# Altium schematic sheet IOs.SchDoc
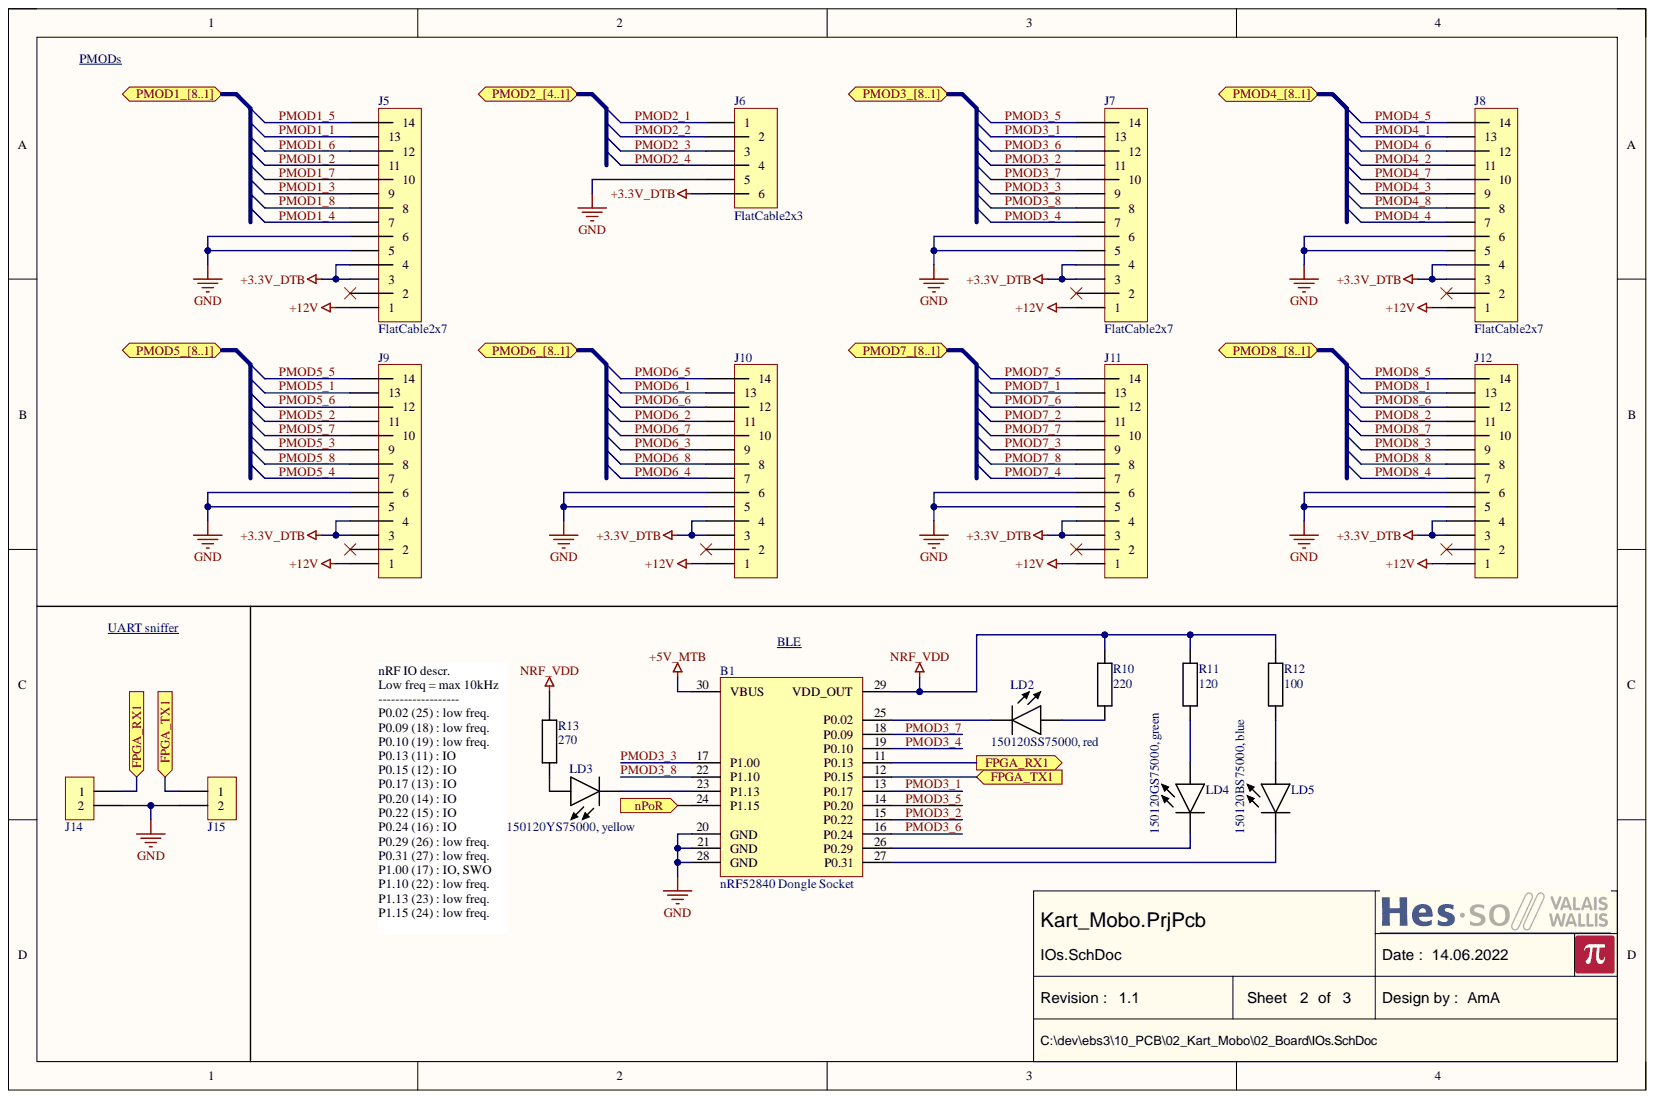
[diagram p0: IOs.SchDoc]

## Parts
B1  nRF52840 Dongle Socket  [nRF52840 Dongle socket]
J5  FlatCable2x7  [FlatCable2x7 2.54MM Straight TH]
J6  FlatCable2x3  [FlatCable2x3 2.54MM Straight TH]
J7  FlatCable2x7  [FlatCable2x7 2.54MM Straight TH]
J8  FlatCable2x7  [FlatCable2x7 2.54MM Straight TH]
J9  Socket2x7  [Socket2X7 P2.54 RIGHT ANGLE]  (value not drawn)
J10  Socket2x7  [Socket2X7 P2.54 RIGHT ANGLE]  (value not drawn)
J11  Socket2x7  [Socket2X7 P2.54 RIGHT ANGLE]  (value not drawn)
J12  Socket2x7  [Socket2X7 P2.54 RIGHT ANGLE]  (value not drawn)
J14  (no value)  [PinHeader1x2 P2.54]
J15  (no value)  [PinHeader1x2 P2.54]
LD2  150120SS75000, red  [LED 3216 REFLOW]
LD3  150120YS75000, yellow  [LED 3216 REFLOW]
LD4  150120GS75000, green  [LED 3216 REFLOW]
LD5  150120BS75000, blue  [LED 3216 REFLOW]
R10  220  [RESISTOR 1608 REFLOW]
R11  120  [RESISTOR 1608 REFLOW]
R12  100  [RESISTOR 1608 REFLOW]
R13  270  [RESISTOR 1608 REFLOW]

## Ports
FPGA_RX1, FPGA_TX1, nPoR, PMOD1_1, PMOD1_2, PMOD1_3, PMOD1_4, PMOD1_5, PMOD1_6, PMOD1_7, PMOD1_8, PMOD1_[8..1], PMOD2_1, PMOD2_2, PMOD2_3, PMOD2_4, PMOD2_[4..1], PMOD3_1, PMOD3_2, PMOD3_3, PMOD3_4, PMOD3_5, PMOD3_6, PMOD3_7, PMOD3_8, PMOD3_[8..1], PMOD4_1, PMOD4_2, PMOD4_3, PMOD4_4, PMOD4_5, PMOD4_6, PMOD4_7, PMOD4_8, PMOD4_[8..1], PMOD5_1, PMOD5_2, PMOD5_3, PMOD5_4, PMOD5_5, PMOD5_6, PMOD5_7, PMOD5_8, PMOD5_[8..1], PMOD6_1, PMOD6_2, PMOD6_3, PMOD6_4, PMOD6_5, PMOD6_6, PMOD6_7, PMOD6_8, PMOD6_[8..1], PMOD7_1, PMOD7_2, PMOD7_3, PMOD7_4, PMOD7_5, PMOD7_6, PMOD7_7, PMOD7_8, PMOD7_[8..1], PMOD8_1, PMOD8_2, PMOD8_3, PMOD8_4, PMOD8_5, PMOD8_6, PMOD8_7, PMOD8_8, PMOD8_[8..1]

## Nets
+3.3V_DTB: J5.3 J5.4 J6.6 J7.3 J7.4 J8.3 J8.4 J9.3 J9.4 J10.3 J10.4 J11.3 J11.4 J12.3 J12.4
+5V_MTB: B1.30(VBUS)
+12V: J5.1 J7.1 J8.1 J9.1 J10.1 J11.1 J12.1
GND: B1.20(GND) B1.21(GND) B1.28(GND) J5.5 J5.6 J6.5 J7.5 J7.6 J8.5 J8.6 J9.5 J9.6 J10.5 J10.6 J11.5 J11.6 J12.5 J12.6 J14.2 J15.2
NRF_VDD: B1.29(VDD_OUT) R10.1 R11.1 R12.2 R13.2
FPGA_RX1: B1.11(P0.13) J14.1 ; port FPGA_RX1
FPGA_TX1: B1.12(P0.15) J15.1 ; port FPGA_TX1
nPoR: B1.24(P1.15) ; port nPoR
PMOD1_1: J5.13 ; port PMOD1_[8..1]  [also labelled ]
PMOD1_2: J5.11 ; port PMOD1_[8..1]  [also labelled ]
PMOD1_3: J5.9 ; port PMOD1_[8..1]  [also labelled ]
PMOD1_4: J5.7 ; port PMOD1_[8..1]  [also labelled ]
PMOD1_5: J5.14 ; port PMOD1_[8..1]  [also labelled ]
PMOD1_6: J5.12 ; port PMOD1_[8..1]  [also labelled ]
PMOD1_7: J5.10 ; port PMOD1_[8..1]  [also labelled ]
PMOD1_8: J5.8 ; port PMOD1_[8..1]  [also labelled ]
PMOD2_1: J6.1 ; port PMOD2_[4..1]  [also labelled ]
PMOD2_2: J6.2 ; port PMOD2_[4..1]  [also labelled ]
PMOD2_3: J6.3 ; port PMOD2_[4..1]  [also labelled ]
PMOD2_4: J6.4 ; port PMOD2_[4..1]  [also labelled ]
PMOD3_1: B1.13(P0.17) J7.13 ; port PMOD3_[8..1]  [also labelled ]
PMOD3_2: B1.15(P0.22) J7.11 ; port PMOD3_[8..1]  [also labelled ]
PMOD3_3: B1.17(P1.00) J7.9 ; port PMOD3_[8..1]  [also labelled ]
PMOD3_4: B1.19(P0.10) J7.7 ; port PMOD3_[8..1]  [also labelled ]
PMOD3_5: B1.14(P0.20) J7.14 ; port PMOD3_[8..1]  [also labelled ]
PMOD3_6: B1.16(P0.24) J7.12 ; port PMOD3_[8..1]  [also labelled ]
PMOD3_7: B1.18(P0.09) J7.10 ; port PMOD3_[8..1]  [also labelled ]
PMOD3_8: B1.22(P1.10) J7.8 ; port PMOD3_[8..1]  [also labelled ]
PMOD4_1: J8.13 ; port PMOD4_[8..1]  [also labelled ]
PMOD4_2: J8.11 ; port PMOD4_[8..1]  [also labelled ]
PMOD4_3: J8.9 ; port PMOD4_[8..1]  [also labelled ]
PMOD4_4: J8.7 ; port PMOD4_[8..1]  [also labelled ]
PMOD4_5: J8.14 ; port PMOD4_[8..1]  [also labelled ]
PMOD4_6: J8.12 ; port PMOD4_[8..1]  [also labelled ]
PMOD4_7: J8.10 ; port PMOD4_[8..1]  [also labelled ]
PMOD4_8: J8.8 ; port PMOD4_[8..1]  [also labelled ]
PMOD5_1: J9.13 ; port PMOD5_[8..1]  [also labelled ]
PMOD5_2: J9.11 ; port PMOD5_[8..1]  [also labelled ]
PMOD5_3: J9.9 ; port PMOD5_[8..1]  [also labelled ]
PMOD5_4: J9.7 ; port PMOD5_[8..1]  [also labelled ]
PMOD5_5: J9.14 ; port PMOD5_[8..1]  [also labelled ]
PMOD5_6: J9.12 ; port PMOD5_[8..1]  [also labelled ]
PMOD5_7: J9.10 ; port PMOD5_[8..1]  [also labelled ]
PMOD5_8: J9.8 ; port PMOD5_[8..1]  [also labelled ]
PMOD6_1: J10.13 ; port PMOD6_[8..1]  [also labelled ]
PMOD6_2: J10.11 ; port PMOD6_[8..1]  [also labelled ]
PMOD6_3: J10.9 ; port PMOD6_[8..1]  [also labelled ]
PMOD6_4: J10.7 ; port PMOD6_[8..1]  [also labelled ]
PMOD6_5: J10.14 ; port PMOD6_[8..1]  [also labelled ]
PMOD6_6: J10.12 ; port PMOD6_[8..1]  [also labelled ]
PMOD6_7: J10.10 ; port PMOD6_[8..1]  [also labelled ]
PMOD6_8: J10.8 ; port PMOD6_[8..1]  [also labelled ]
PMOD7_1: J11.13 ; port PMOD7_[8..1]  [also labelled ]
PMOD7_2: J11.11 ; port PMOD7_[8..1]  [also labelled ]
PMOD7_3: J11.9 ; port PMOD7_[8..1]  [also labelled ]
PMOD7_4: J11.7 ; port PMOD7_[8..1]  [also labelled ]
PMOD7_5: J11.14 ; port PMOD7_[8..1]  [also labelled ]
PMOD7_6: J11.12 ; port PMOD7_[8..1]  [also labelled ]
PMOD7_7: J11.10 ; port PMOD7_[8..1]  [also labelled ]
PMOD7_8: J11.8 ; port PMOD7_[8..1]  [also labelled ]
PMOD8_1: J12.13 ; port PMOD8_[8..1]  [also labelled ]
PMOD8_2: J12.11 ; port PMOD8_[8..1]  [also labelled ]
PMOD8_3: J12.9 ; port PMOD8_[8..1]  [also labelled ]
PMOD8_4: J12.7 ; port PMOD8_[8..1]  [also labelled ]
PMOD8_5: J12.14 ; port PMOD8_[8..1]  [also labelled ]
PMOD8_6: J12.12 ; port PMOD8_[8..1]  [also labelled ]
PMOD8_7: J12.10 ; port PMOD8_[8..1]  [also labelled ]
PMOD8_8: J12.8 ; port PMOD8_[8..1]  [also labelled ]
NetB1_23: B1.23(P1.13) LD3.1
NetB1_25: B1.25(P0.02) LD2.1
NetB1_26: B1.26(P0.29) LD4.1
NetB1_27: B1.27(P0.31) LD5.1
NetLD2_2: LD2.2 R10.2
NetLD3_2: LD3.2 R13.1
NetLD4_2: LD4.2 R11.2
NetLD5_2: LD5.2 R12.1

Not connected: J5 2; J7 2; J8 2; J9 2; J10 2; J11 2; J12 2

## Library data of the parts
J9-J12 = Socket2x7: Receptacle connector; Component Kind: Standard; Library Name: PinHeader.IntLib
J14 J15 = PinHeader1x2: Plug connector; Component Kind: Standard; Library Name: PinHeader.IntLib
LD2-LD5 = LED: LED generic; Component Kind: Standard; Library Name: Diode.IntLib
  LD2: Value: 150120SS75000, red
  LD3: Value: 150120YS75000, yellow
  LD4: Value: 150120GS75000, green
  LD5: Value: 150120BS75000, blue
R10-R13 = Resistor: Component Kind: Standard; Description: Resistor; Library Name: Resistor.IntLib
  R10: Value: 220
  R11: Value: 120
  R12: Value: 100
  R13: Value: 270
B1 = nRF52840 Dongle Socket: Component Kind: Standard; Description: nRF52840 Dongle Socket; Library Name: DevBoard.IntLib; Value: nRF52840 Dongle Socket
J5 J7 J8 = FlatCable2x7: Component Kind: Standard; Description: FlatCable2x7; Library Name: Connector.IntLib
J6 = FlatCable2x3: Component Kind: Standard; Description: FlatCable2x3; Library Name: Connector.IntLib
